# Altium schematic sheet Power Supply3.SchDoc("Power Supply3")
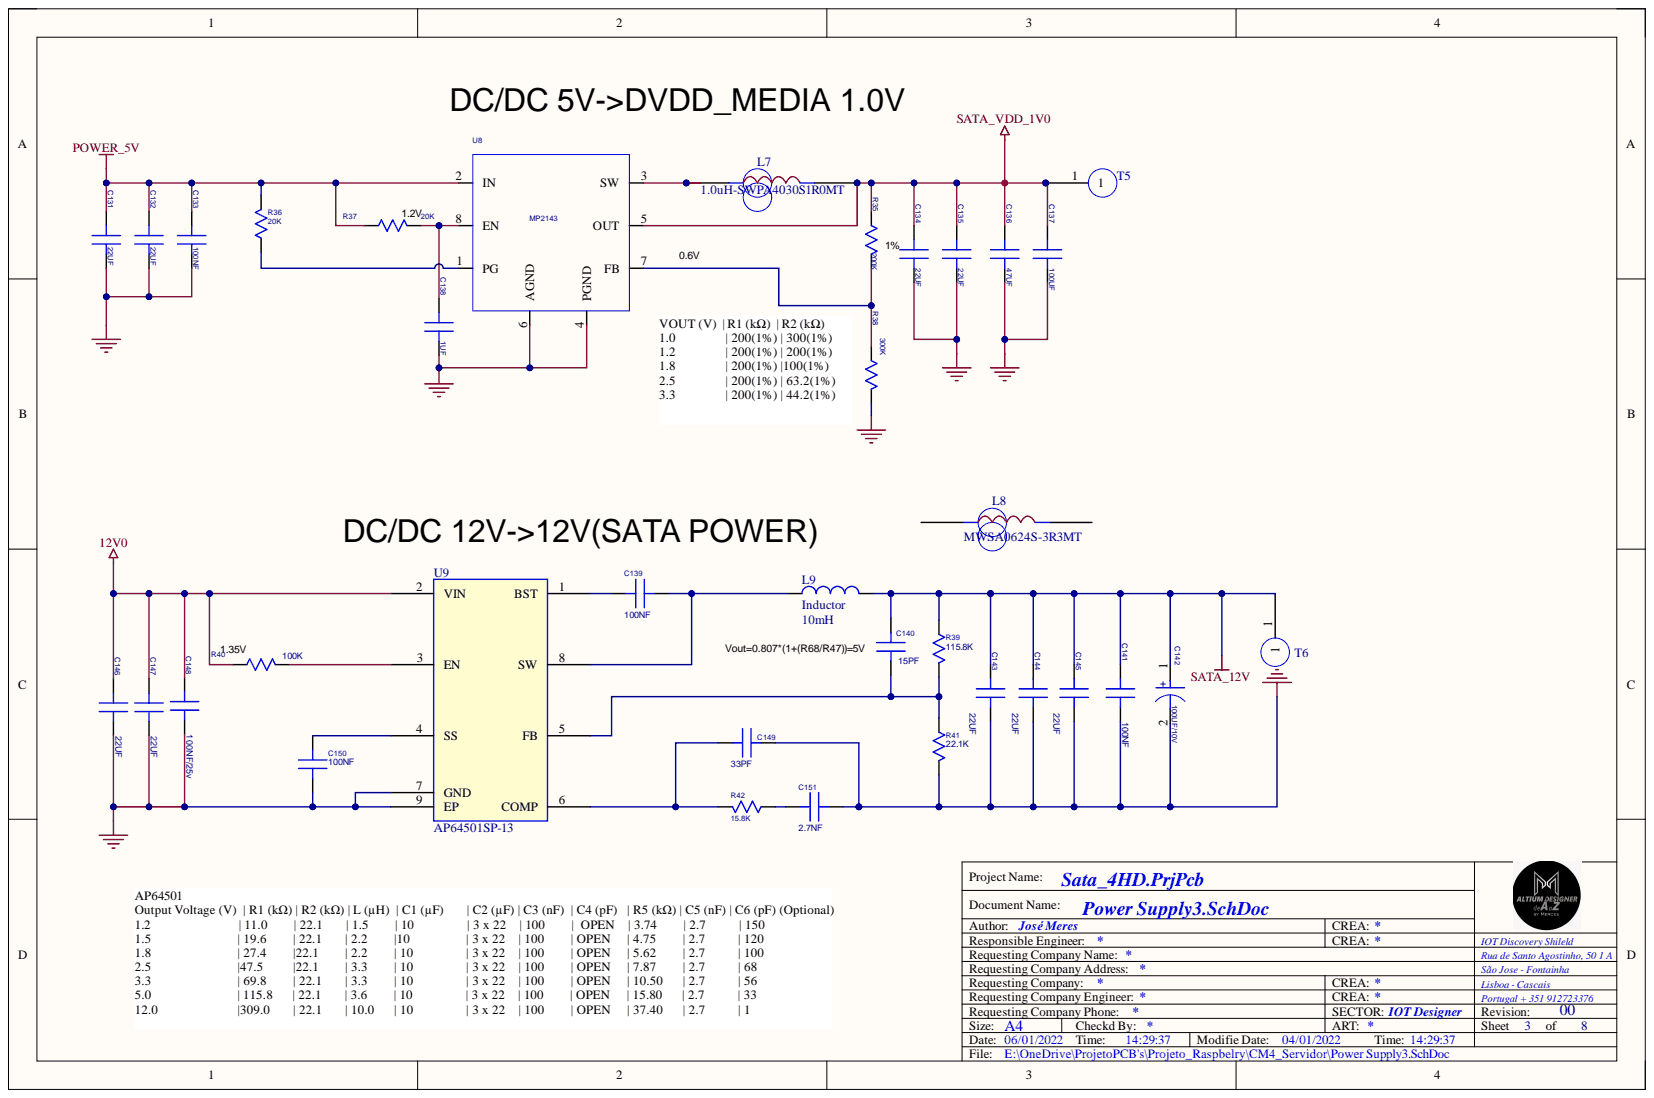
[diagram p0: Power Supply3.SchDoc]

## Parts
C131  22UF  [C0805-ROUND]
C132  22UF  [C0805-ROUND]
C133  100NF  [C0805-ROUND]
C134  22UF  [C0805-ROUND]
C135  22UF  [C0805-ROUND]
C136  47UF  [C0805-ROUND]
C137  100UF  [C0805-ROUND]
C138  1UF  [C0402-ROUND]
C139  100NF  [C0402-ROUND]
C140  15PF  [C0402-ROUND]
C141  100NF  [C0402-ROUND]
C142  100UF/10V  [Capacitor SMD Eletrolitic-D8.0x10]
C143  22UF  [C0805-ROUND]
C144  22UF  [C0805-ROUND]
C145  22UF  [C0805-ROUND]
C146  22UF  [C0805-ROUND]
C147  22UF  [C0805-ROUND]
C148  100NF/25v  [C0805-ROUND]
C149  33PF  [C0402-ROUND]
C150  100NF  [C0402-ROUND]
C151  2.7NF  [C0402-ROUND]
L7  1.0uH-SWPA4030S1R0MT  [NRS3015T2R2MNGHV]
L8  MWSA0624S-3R3MT  [NRS3015T2R2MNGHV]
L9  Inductor  value 10mH  [NR6045-220M]
R35  200K  [R0402-ROUND]
R36  20K  [R0402-ROUND]
R37  20K  [R0402-ROUND]
R38  300K  [R0402-ROUND]
R39  115.8K  [R0402-ROUND]
R40  100K  [R0402-ROUND]
R41  22.1K  [R0402-ROUND]
R42  15.8K  [R0402-ROUND]
T5  TEST  [TEST_40]  (value not drawn)
T6  TEST  [TEST_40]  (value not drawn)
U8  MP2143  [SOT23-8]
U9  AP64501SP-13  [SO8-EP]

## Nets
12V0: C146.1 C147.1 C148.1 R40.1 U9.2(VIN)
GND: C131.2 C132.2 C133.2 C134.2 C135.2 C136.2 C137.2 C138.2 C141.2 C142.2 C143.2 C144.2 C145.2 C146.2 C147.2 C148.2 C149.2 C150.2 C151.2 R38.2 R41.2 U8.4(PGND) U8.6(AGND) U9.7(GND) U9.9(EP)
POWER_5V: C131.1 C132.1 C133.1 R36.2 R37.1 U8.2(IN)
SATA_12V: C140.1 C141.1 C142.1 C143.1 C144.1 C145.1 L9.2 R39.1 T6.1
SATA_VDD_1V0: C134.1 C135.1 C136.1 C137.1 L7.2 R35.1 T5.1 U8.5(OUT)
NetC138_1: C138.1 R37.2 U8.8(EN)
NetC139_1: C139.1 U9.1(BST)
NetC139_2: C139.2 L9.1 U9.8(SW)
NetC140_2: C140.2 R39.2 R41.1 U9.5(FB)
NetC149_1: C149.1 R42.2 U9.6(COMP)
NetC150_1: C150.1 U9.4(SS)
NetC151_1: C151.1 R42.1
NetL7_1: L7.1 U8.3(SW)
NetR35_2: R35.2 R38.1 U8.7(FB)
NetR36_1: R36.1 U8.1(PG)
NetR40_2: R40.2 U9.3(EN)

Not connected: L8 1 2

## Library data of the parts
C131 C132 = C_0_Dup3: PARTS: 1; %182%%238%%182%%168%%185%%164%%215%%247%%181%%231%%209%%185%(V): 6.3; %195%%232%%202%%246%: SMD陶瓷电容-6.3V-22000NF-+/-20%-X5R-1206-BT,TS16949; %208%%161%%192%%224%%195%%251%%179%%198%: SMD陶瓷电容; CODE: 8070630; COMPONENT_HIGH_MAX: 1.9MM; DEVICE: GRM31CR60J226ME19L; LEVEL: STD; OriginalSymbolOrigin: (0,30); PCB Footprint: SC0603; PINSWAP: (A,B); PKG_TYPE: SC0805; Component Kind: Standard
C133 = C_0_Dup3: PARTS: 1; %182%%238%%182%%168%%185%%164%%215%%247%%181%%231%%209%%185%(V): 16; %195%%232%%202%%246%: SMD陶瓷电容-16V-100NF-+/-10%-X7R-0402-BT; %208%%161%%192%%224%%195%%251%%179%%198%: SMD陶瓷电容; CODE: 8070614; COMPONENT_HIGH_MAX: 0.55MM; DEVICE: RMEMK105BJ104KV_FR; LEVEL: STD; OriginalSymbolOrigin: (0,30); PCB Footprint: SC0402; PINSWAP: (A,B); Component Kind: Standard; PKG_TYPE: SC0402
C134 = C_0_Dup3: PARTS: 1; %182%%238%%182%%168%%185%%164%%215%%247%%181%%231%%209%%185%(V): 6.3; %195%%232%%202%%246%: SMD陶瓷电容-6.3V-22000NF-+/-20%-X5R-1206-BT,TS16949; %208%%161%%192%%224%%195%%251%%179%%198%: SMD陶瓷电容; CODE: 8070630; COMPONENT_HIGH_MAX: 1.9MM; DEVICE: GRM31CR60J226ME19L; LEVEL: STD; OriginalSymbolOrigin: (0,30); PCB Footprint: SC0805; PINSWAP: (A,B); Component Kind: Standard; PKG_TYPE: SC0805
C135 = C_0_Dup3: PARTS: 1; %182%%238%%182%%168%%185%%164%%215%%247%%181%%231%%209%%185%(V): 6.3; %195%%232%%202%%246%: SMD陶瓷电容-6.3V-22000NF-+/-20%-X5R-1206-BT,TS16949; %208%%161%%192%%224%%195%%251%%179%%198%: SMD陶瓷电容; CODE: 8070630; COMPONENT_HIGH_MAX: 1.9MM; DEVICE: GRM31CR60J226ME19L; LEVEL: STD; OriginalSymbolOrigin: (0,30); PCB Footprint: SC0805; PINSWAP: (A,B); VARIANT_ID: $37I1947; Component Kind: Standard; PKG_TYPE: SC0805
C136 = C_0_Dup3: PARTS: 1; %182%%238%%182%%168%%185%%164%%215%%247%%181%%231%%209%%185%(V): 6.3; %195%%232%%202%%246%: SMD陶瓷电容-6.3V-47000nF-+/-20%-X6S-1206-供电专用; %208%%161%%192%%224%%195%%251%%179%%198%: SMD陶瓷电容; CODE: 08071639; COMPONENT_HIGH_MAX: 1.9MM; DEVICE: GRM31CR60J226ME19L; LEVEL: STD; OriginalSymbolOrigin: (0,30); PCB Footprint: SC0805; PINSWAP: (A,B); Component Kind: Standard; PKG_TYPE: SC0805
C137 = C_0_Dup3: PARTS: 1; %182%%238%%182%%168%%185%%164%%215%%247%%181%%231%%209%%185%(V): 6.3; %195%%232%%202%%246%: SMD陶瓷电容-4V-100000nF-+/-20%-X6T-1206; %208%%161%%192%%224%%195%%251%%179%%198%: SMD陶瓷电容; CODE: 8120045; COMPONENT_HIGH_MAX: 1.9MM; DEVICE: GRM31CR60J226ME19L; LEVEL: STD; OriginalSymbolOrigin: (0,30); PCB Footprint: SC1206; PINSWAP: (A,B); VARIANT_ID: $37I2400; Component Kind: Standard; PKG_TYPE: SC1206
C138 = C_0_Dup3: PARTS: 1; %182%%238%%182%%168%%185%%164%%215%%247%%181%%231%%209%%185%(V): 10; %195%%232%%202%%246%: SMD陶瓷电容-10V-1000nF-+/-10%-X5R-0402-BT,TS16949; %208%%161%%192%%224%%195%%251%%179%%198%: SMD陶瓷电容; CODE: 8070612; COMPONENT_HIGH_MAX: 0.55mm; DEVICE: CV05X5R105K10AH; LEVEL: STD; OriginalSymbolOrigin: (0,30); PCB Footprint: SC0402; PINSWAP: (A,B); VARIANT_ID: $38I2629; Component Kind: Standard; PKG_TYPE: SC0402
C139 C149-C151 = C_0_Dup1: PARTS: 1; %182%%238%%182%%168%%185%%164%%215%%247%%181%%231%%209%%185%(V): 16; %195%%232%%202%%246%: SMD陶瓷电容-16V-100NF-+/-10%-X7R-0402-BT; %208%%161%%192%%224%%195%%251%%179%%198%: SMD陶瓷电容; CODE: 8070614; COMPONENT_HIGH_MAX: 0.55MM; DEVICE: RMEMK105BJ104KV_FR; LEVEL: STD; OriginalSymbolOrigin: (0,30); PCB Footprint: SC0402; PINSWAP: (A,B); Component Kind: Standard; PKG_TYPE: SC0402
C140 = C_0_Dup1: PARTS: 1; %182%%238%%182%%168%%185%%164%%215%%247%%181%%231%%209%%185%(V): 50; %195%%232%%202%%246%: SMD陶瓷电容-50V-0.015NF-+/-5%-NP0-0402; %208%%161%%192%%224%%195%%251%%179%%198%: SMD陶瓷电容; CODE: 8070544; COMPONENT_HIGH_MAX: 0.55MM; DEVICE: C0402C150J5GAC_7867; LEVEL: STD; OriginalSymbolOrigin: (0,30); PCB Footprint: SC0402; PINSWAP: (A,B); Component Kind: Standard; PKG_TYPE: SC0402
C141 C148 = C_0_Dup1: PARTS: 1; %182%%238%%182%%168%%185%%164%%215%%247%%181%%231%%209%%185%(V): 50; %195%%232%%202%%246%: SMD陶瓷电容-50V-100NF-+/-10%-X7R-0603; %208%%161%%192%%224%%195%%251%%179%%198%: SMD陶瓷电容; CODE: 8070627; COMPONENT_HIGH_MAX: 0.9MM; DEVICE: C1608X7R1H104KT000N; LEVEL: STD; OriginalSymbolOrigin: (0,30); PCB Footprint: SC0402; PINSWAP: (A,B); PKG_TYPE: SC0603; Component Kind: Standard
C142 = CP_0: PARTS: 1; %182%%238%%182%%168%%185%%164%%215%%247%%181%%231%%209%%185%(V): 25; %195%%232%%202%%246%: 非固体SMD铝电容-25V-220UF-+/-20%-8*10.5-8.3*8.3MM-极性-105DEGC-1000H-SMD-温度敏感器件-工艺耐温250DEGC,5S; %208%%161%%192%%224%%195%%251%%179%%198%: 非固体SMD铝电容; CODE: 8120004; COMPONENT_HIGH_MAX: 10.5MM; DEVICE: EMVE250ADA221MHA0G; LEVEL: STD; OriginalSymbolOrigin: (0,30); PCB Footprint: ct7343; PKG_TYPE: SCAPC2-280-327; Component Kind: Standard
C143-C145 = C_0_Dup1: PARTS: 1; %182%%238%%182%%168%%185%%164%%215%%247%%181%%231%%209%%185%(V): 6.3; %195%%232%%202%%246%: SMD陶瓷电容-6.3V-47000NF-+/-20%-X6S-1206-供电专用; %208%%161%%192%%224%%195%%251%%179%%198%: SMD陶瓷电容; CODE: 8071639; COMPONENT_HIGH_MAX: 1.8MM; DEVICE: C3216X6S0J476MTJ00N; LEVEL: STD; OriginalSymbolOrigin: (0,30); PCB Footprint: SC0805; PINSWAP: (A,B); Component Kind: Standard; PKG_TYPE: SC0805
C146 C147 = C_0_Dup1: PARTS: 1; %182%%238%%182%%168%%185%%164%%215%%247%%181%%231%%209%%185%(V): 25; %195%%232%%202%%246%: SMD陶瓷电容-25V-22000NF-+/-10%-X7R-1210-所有; %208%%161%%192%%224%%195%%251%%179%%198%: SMD陶瓷电容; CODE: 8070825; COMPONENT_HIGH_MAX: 2.7MM; DEVICE: CETMK325B7226KM_T; LEVEL: STD; OriginalSymbolOrigin: (0,30); PCB Footprint: SC1206; PINSWAP: (A,B); Component Kind: Standard; PKG_TYPE: SC1206
L7 = SWPA4030S: 贴片箌率电感; SIGNAL_MODEL: DEFAULT_INDUCTOR_1e+010nH_1_2; Component Kind: Standard; PCB Footprint: swpa4030s
L8 = SWPA4030S: 贴片箌率电感; PCB Footprint: SPL0707G; SIGNAL_MODEL: DEFAULT_INDUCTOR_1e+010nH_1_2; Component Kind: Standard
L9 = Inductor: Code_IEC: 1005; Code_JEITA: 0402; Component Kind: Standard; Description: Inductor; LatestRevisionDate: 17-Jul-2002; LatestRevisionNote: Re-released for DXP Platform.; PackageReference: 0402-A; Published: 8-Jun-2000; Signal Integrity: Inductor; Simulation: INDUCTOR; Library Name: Merces_Library.SchLib; Publisher: Altium Limited
R35 = R_0_Dup2: PARTS: 1; %182%%238%%182%%168%%185%%166%%194%%202%(W): 0.1; %195%%232%%202%%246%: 片式厚膜电阻器-0.1W-12700OHM-+/-1%-0603--供电专用-07090924-供电专用; %208%%161%%192%%224%%195%%251%%179%%198%: 片式厚膜电阻器; %215%%232%%214%%181%%212%%202%%208%%237%%198%%171%%178%%238%: +/-1%; CODE: 7091203; COMPONENT_HIGH_MAX: 0.45MM; DEVICE: RC0603FR_0712K7L; LEVEL: STD; OriginalSymbolOrigin: (10,20); PCB Footprint: SR0402; PINSWAP: (A,B); PKG_TYPE: SR0603; Component Kind: Standard
R36 R37 = R_0_Dup2: PARTS: 1; %195%%232%%202%%246%: 片式厚膜电阻器-0.0625W-20000OHM-+/-1%-0402; %208%%161%%192%%224%%195%%251%%179%%198%: 片式厚膜电阻器; CODE: 7091374; COMPONENT_HIGH_MAX: 999999MM; DEVICE: RC_02W2002FT; LEVEL: STD; OriginalSymbolOrigin: (10,20); PCB Footprint: SR0402; PINSWAP: (A,B); Component Kind: Standard; PKG_TYPE: SR0402
R38 = R_0_Dup2: PARTS: 1; %195%%232%%202%%246%: 片式厚膜电阻器-0.1W-33200ohm-+/-1%-0603; %208%%161%%192%%224%%195%%251%%179%%198%: 片式厚膜电阻器; CODE: 7090937; COMPONENT_HIGH_MAX: 0.55MM; DEVICE: RC0603FR_0733K2; LEVEL: STD; OriginalSymbolOrigin: (10,20); PCB Footprint: SR0402; PINSWAP: (A,B); PKG_TYPE: SR0603; Component Kind: Standard
R39 = R_0_Dup1: PARTS: 1; %182%%238%%182%%168%%185%%166%%194%%202%(W): 0.1; %195%%232%%202%%246%: 片式厚膜电阻器-0.1W-33200OHM-+/-1%-0603; %208%%161%%192%%224%%195%%251%%179%%198%: 片式厚膜电阻器; %215%%232%%214%%181%%212%%202%%208%%237%%198%%171%%178%%238%: +/-1%; CODE: 7090766; COMPONENT_HIGH_MAX: 0.55MM; DEVICE: RC0603FR_0733K2; LEVEL: STD; OriginalSymbolOrigin: (10,20); PCB Footprint: SR0402; PINSWAP: (A,B); Component Kind: Standard; PKG_TYPE: SR0402
R40 = R_0_Dup1: PARTS: 1; %182%%238%%182%%168%%185%%166%%194%%202%(W): 0.06; %195%%232%%202%%246%: 片式厚膜电阻器-0.0625W-100000OHM-+/-5%-0402-BT; %208%%161%%192%%224%%195%%251%%179%%198%: 片式厚膜电阻器; %215%%232%%214%%181%%212%%202%%208%%237%%198%%171%%178%%238%: +/-5%; CODE: 7090831; COMPONENT_HIGH_MAX: 0.37MM; DEVICE: RK73B1ELTP104J; LEVEL: STD; OriginalSymbolOrigin: (10,20); PCB Footprint: SR0402; PINSWAP: (A,B); Component Kind: Standard; PKG_TYPE: SR0402
R41 = R_0_Dup1: PARTS: 1; %182%%238%%182%%168%%185%%166%%194%%202%(W): 0.1; %195%%232%%202%%246%: 片式厚膜电阻器-0.1W-10700OHM-+/-1%-0603; %208%%161%%192%%224%%195%%251%%179%%198%: 片式厚膜电阻器; %215%%232%%214%%181%%212%%202%%208%%237%%198%%171%%178%%238%: +/-1%; CODE: 7090969; COMPONENT_HIGH_MAX: 0.55MM; DEVICE: RC0603FR_0710K7; LEVEL: STD; OriginalSymbolOrigin: (10,20); PCB Footprint: SR0402; PINSWAP: (A,B); PKG_TYPE: SR0603; Component Kind: Standard
R42 = R_0_Dup2: PARTS: 1; %182%%238%%182%%168%%185%%166%%194%%202%(W): 0.1; %195%%232%%202%%246%: 片式厚膜电阻器-0.1W-40200ohm-+/-1%-0603; %208%%161%%192%%224%%195%%251%%179%%198%: 片式厚膜电阻器; %215%%232%%214%%181%%212%%202%%208%%237%%198%%171%%178%%238%: +/-1%; CODE: 7090935; COMPONENT_HIGH_MAX: 0.55mm; DEVICE: RK73H1JTTD4022F; LEVEL: STD; OriginalSymbolOrigin: (10,20); PCB Footprint: SR0402; PINSWAP: (A,B); PKG_TYPE: SR0603; Component Kind: Standard
T5 T6 = TEST: PCB Footprint: tp_40; Component Kind: Standard
U8 = MP2143_0: PARTS: 1; CODE: 99054LFT; LEVEL: STD; OriginalSymbolOrigin: (20,130); PCB Footprint: TSOT23-8; Component Kind: Standard; DEVICE: MP2143; PKG_TYPE: TSOT23-8
U9 = AP64501SP-13: PCB Footprint: SO8EP_P1D27_W4; Component Kind: Standard; Library Name: Merces_Library.SchLib
